# Altium schematic sheet Sheet1.SchDoc
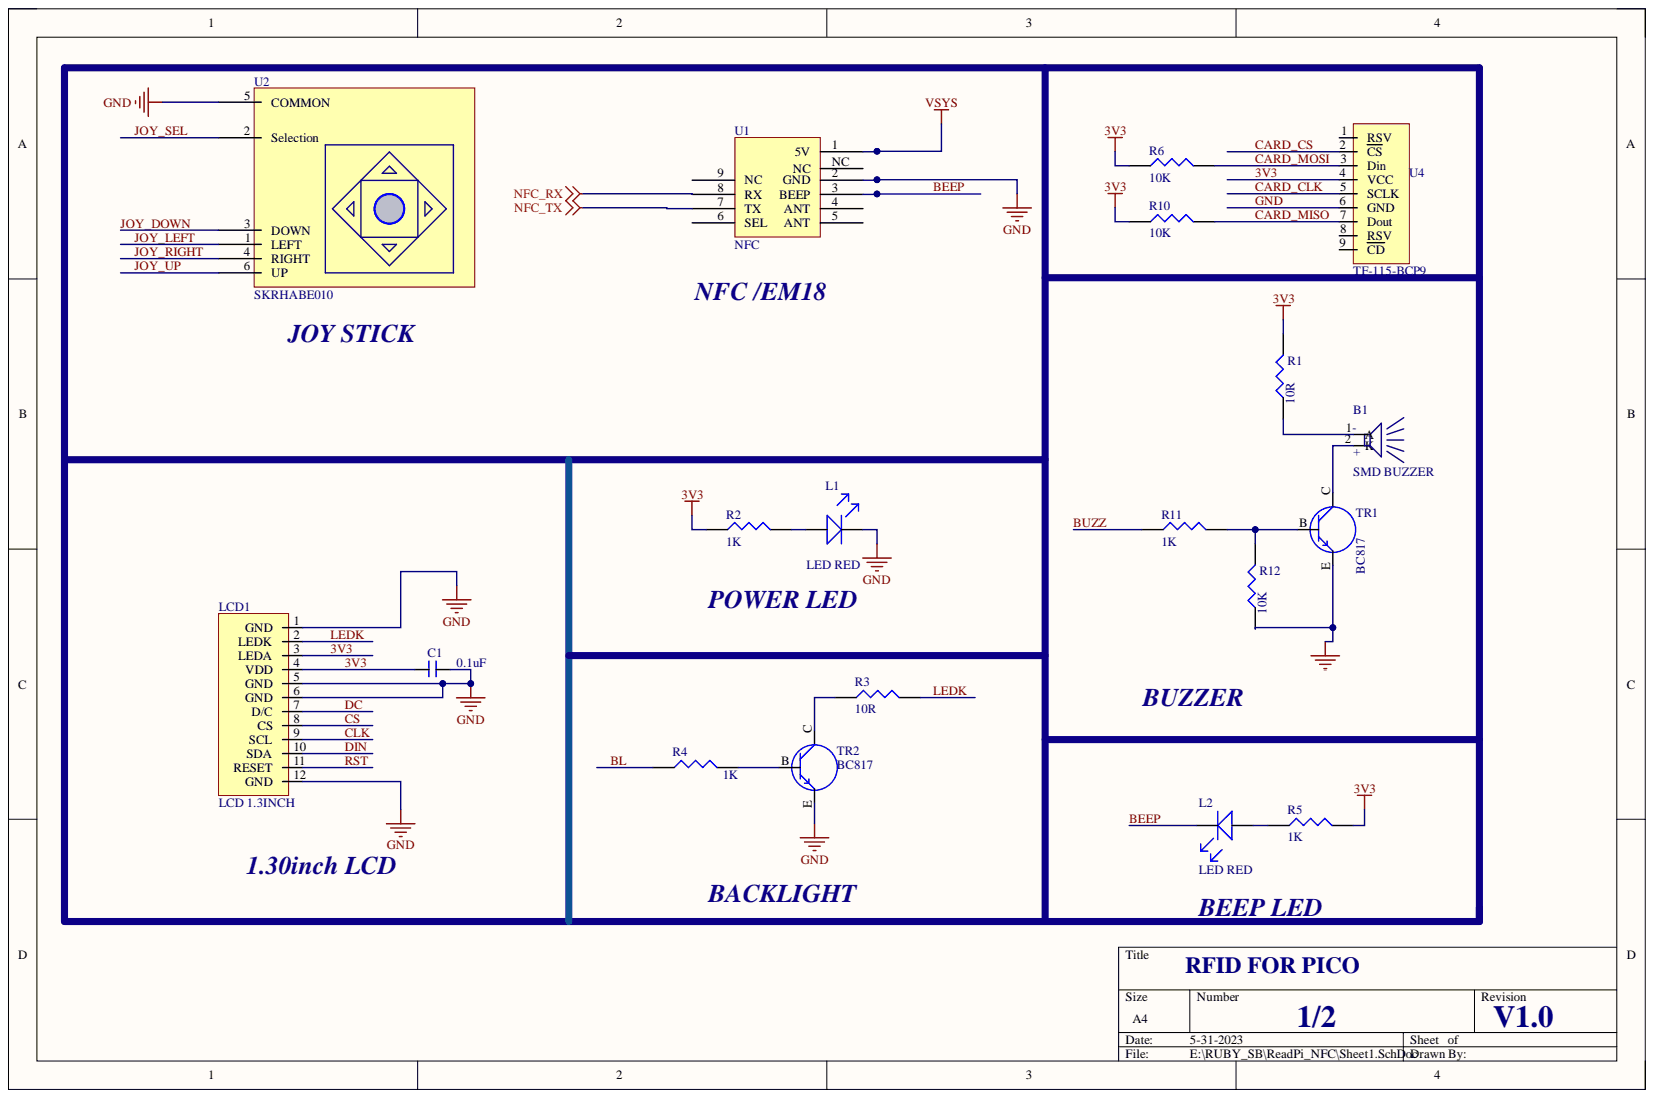
[diagram p0: Sheet1.SchDoc]

## Parts
B1  SMD BUZZER  [SMD BUZZ]
C1  0.1uF  [C_0603]
L1  LED RED  [LED_0603]
L2  LED RED  [LED_0603]
LCD1  LCD 1.3INCH  [1.3 inch LCD]
R1  10R  [R_0603]
R2  1K  [R_0603]
R3  10R  [R_0603]
R4  1K  [R_0603]
R5  1K  [0603]
R6  10K  [R_0603]
R10  10K  [R_0603]
R11  1K  [R_0603]
R12  10K  [R_0603]
TR1  BC817  [SOT23]
TR2  BC817  [SOT23]
U1  NFC  [RFID]
U2  SKRHABE010  [JOY_STICK]
U4  TF-115-BCP9  [micro sd card]

## Nets
NFC_RX: U1.8(RX)
NFC_TX: U1.7(TX)
VSYS: U1.1(5V)
3V3: C1.2 LCD1.3(LEDA) LCD1.4(VDD) R1.2 R2.1 R5.2 R6.1 R10.1 U4.4(VCC)
BEEP: L2.K U1.3(BEEP)
BL: R4.1
BUZZ: R11.1
CARD_CLK: U4.5(SCLK)
CARD_CS: U4.2(CS)
CARD_MISO: R10.2 U4.7(Dout)
CARD_MOSI: R6.2 U4.3(Din)
CLK: LCD1.9(SCL)
CS: LCD1.8(CS)
DC: LCD1.7(D/C)
DIN: LCD1.10(SDA)
GND: C1.1 L1.K LCD1.1(GND) LCD1.5(GND) LCD1.6(GND) LCD1.12(GND) R12.1 TR1.E TR2.E U1.2(GND) U2.5(COMMON) U4.6(GND)
JOY_DOWN: U2.3(DOWN)
JOY_LEFT: U2.1(LEFT)
JOY_RIGHT: U2.4(RIGHT)
JOY_SEL: U2.2(Selection)
JOY_UP: U2.6(UP)
LEDK: LCD1.2(LEDK) R3.2
RST: LCD1.11(RESET)
NetB1_1: B1.1 R1.1
NetB1_2: B1.2 TR1.C
NetL1_A: L1.A R2.2
NetL2_A: L2.A R5.1
NetR3_1: R3.1 TR2.C
NetR4_2: R4.2 TR2.B
NetR11_2: R11.2 R12.2 TR1.B

Not connected: U1 4(ANT) 5(ANT) 6(SEL) 9(NC) NC; U4 1(RSV) 8(RSV) 9(CD)

## Library data of the parts
B1 = BUZZER PIEZO: Component Kind: Standard; Library Name: dxp_pasl_lib.IntLib
C1 = CAP_NONPOLAR: Component Kind: Standard; Library Name: dxp_pasl_lib.IntLib
L1 L2 = LED: Component Kind: Standard; Library Name: dxp_pasl_lib.IntLib
LCD1 = LCD 1.3INCH: 1.3inch LCD, 240RGDX240 DOT MATIRX TFT LCD MODULE; Component Kind: Standard; Library Name: dxp_pasl_lib.IntLib
R1-R6 R10-R12 = RES: Component Kind: Standard; Library Name: dxp_pasl_lib.IntLib
TR1 TR2 = TRANSISTER_NPN: Component Kind: Standard; Library Name: dxp_pasl_lib.IntLib
U1 = NFC: 8MHz XO (Standard) CMOS Oscillator 3.3V Standby (Power Down) 4-SMD, No Lead; Component Kind: Standard; Library Name: MIX.SchLib
U2 = SKRHABE010: SMD 5-way Tactile Switches RoHS #C139794; Component Kind: Standard; Library Name: dxp_pasl_lib.IntLib
U4 = SD CARD TF: Micro SD Memory Card Socket; Component Kind: Standard; Library Name: dxp_pasl_lib.IntLib
